# Altium schematic sheet 2716-2736.SchDoc
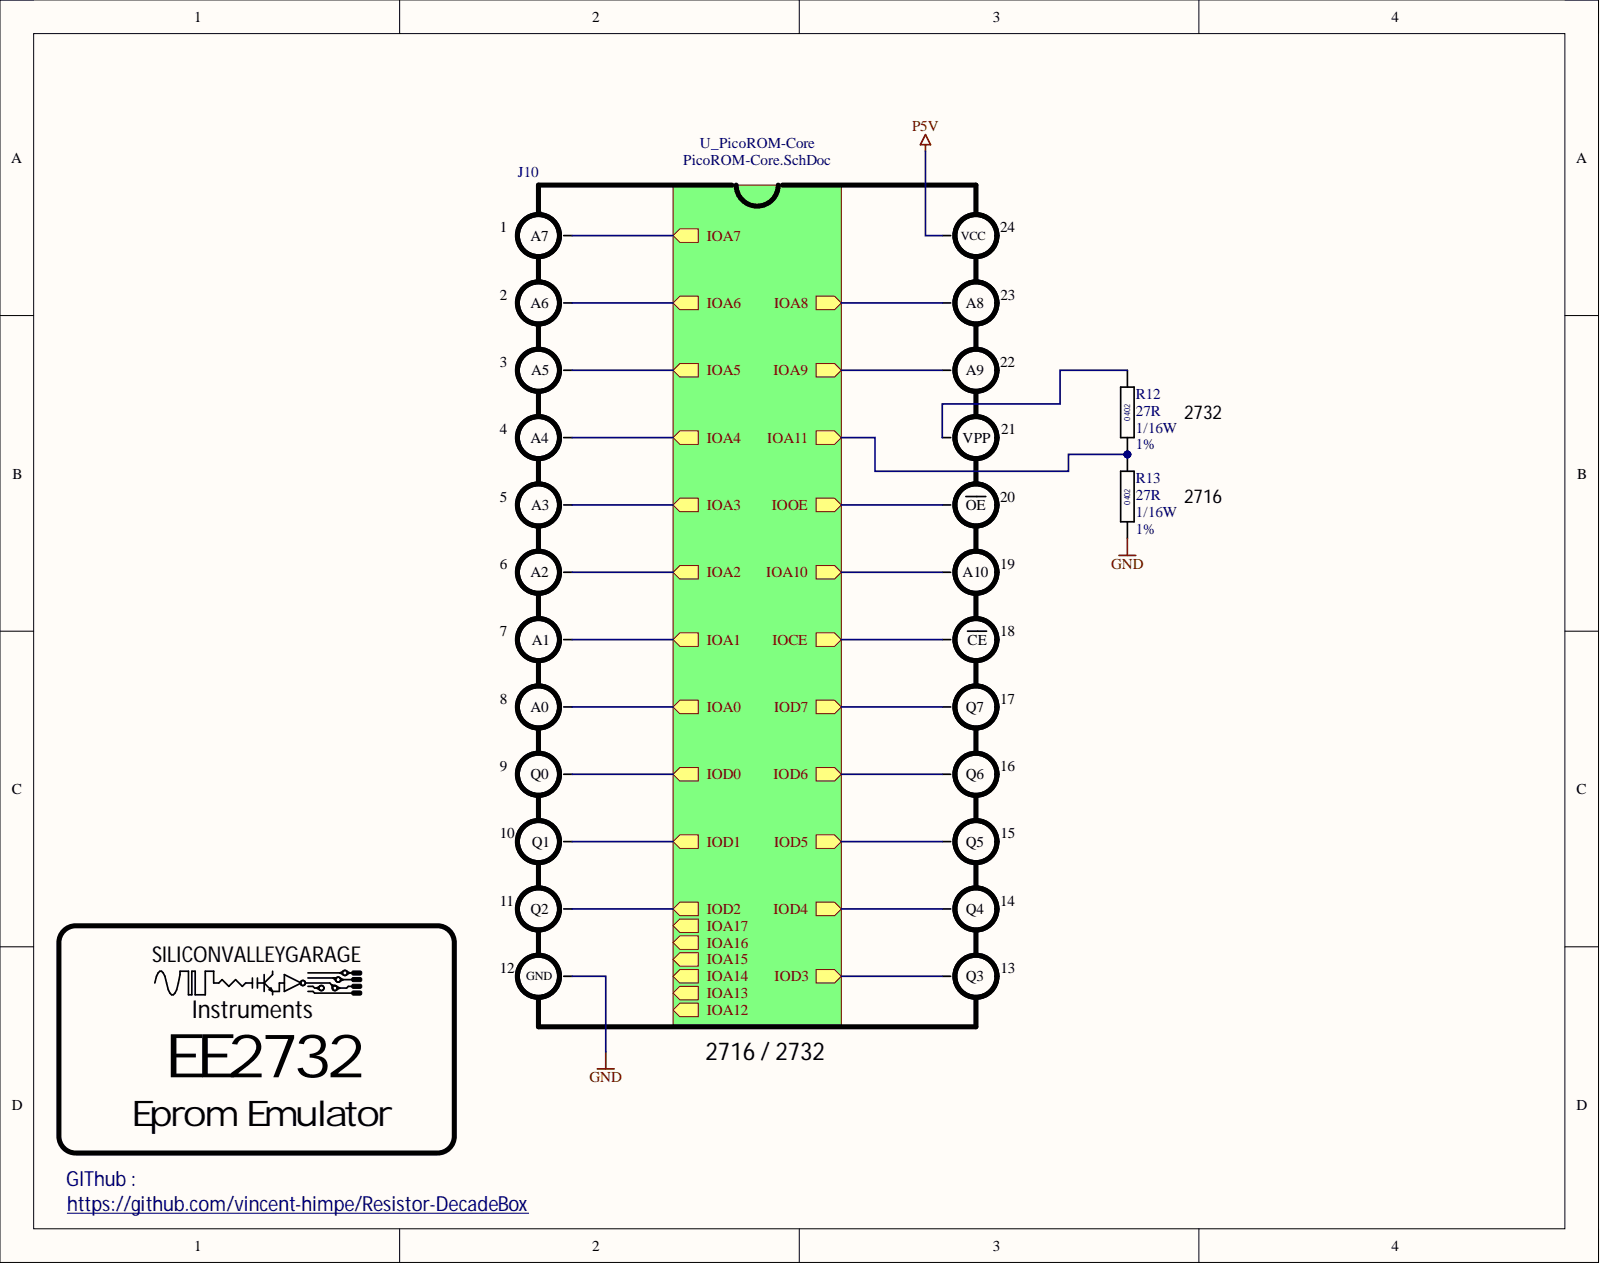
[diagram p0: 2716-2736.SchDoc]

## Parts
J10  IC-EPROM-2716-PINOUT  [DIL24-PLUG]  (value not drawn)
R12  value 27R  Power: 1/16W  Tolerance: 1%  [RESC0402]  (hidden comment: RESC0402)
R13  value 27R  Power: 1/16W  Tolerance: 1%  [RESC0402]  (hidden comment: RESC0402)

## Nets
GND: J10.12 R13.1
P5V: J10.24
NetJ10_1: J10.1
NetJ10_2: J10.2
NetJ10_3: J10.3
NetJ10_4: J10.4
NetJ10_5: J10.5
NetJ10_6: J10.6
NetJ10_7: J10.7
NetJ10_8: J10.8
NetJ10_9: J10.9
NetJ10_10: J10.10
NetJ10_11: J10.11
NetJ10_13: J10.13
NetJ10_14: J10.14
NetJ10_15: J10.15
NetJ10_16: J10.16
NetJ10_17: J10.17
NetJ10_18: J10.18
NetJ10_19: J10.19
NetJ10_20: J10.20
NetJ10_21: J10.21 R12.2
NetJ10_22: J10.22
NetJ10_23: J10.23
NetR12_1: R12.1 R13.2
IOA12:
IOA13:
IOA14:
IOA15:
IOA16:
IOA17:

## Library data of the parts
R12 R13 = RESC-0402-27R-1%-1/10W: RESISTOR,27R,1%,63mW,0402 (1005); Component Kind: Standard; LCSC: C138021; Library Name: RESISTORS.SchLib; Supplier 1: Future Electronics; Supplier Part Number 1: 2577120
J10 = IC-EPROM-2716-PINOUT: Component Kind: Standard; Library Name: IC-MEMORY.SchLib
